# Altium schematic sheet S08_IO_RevF.SchDoc
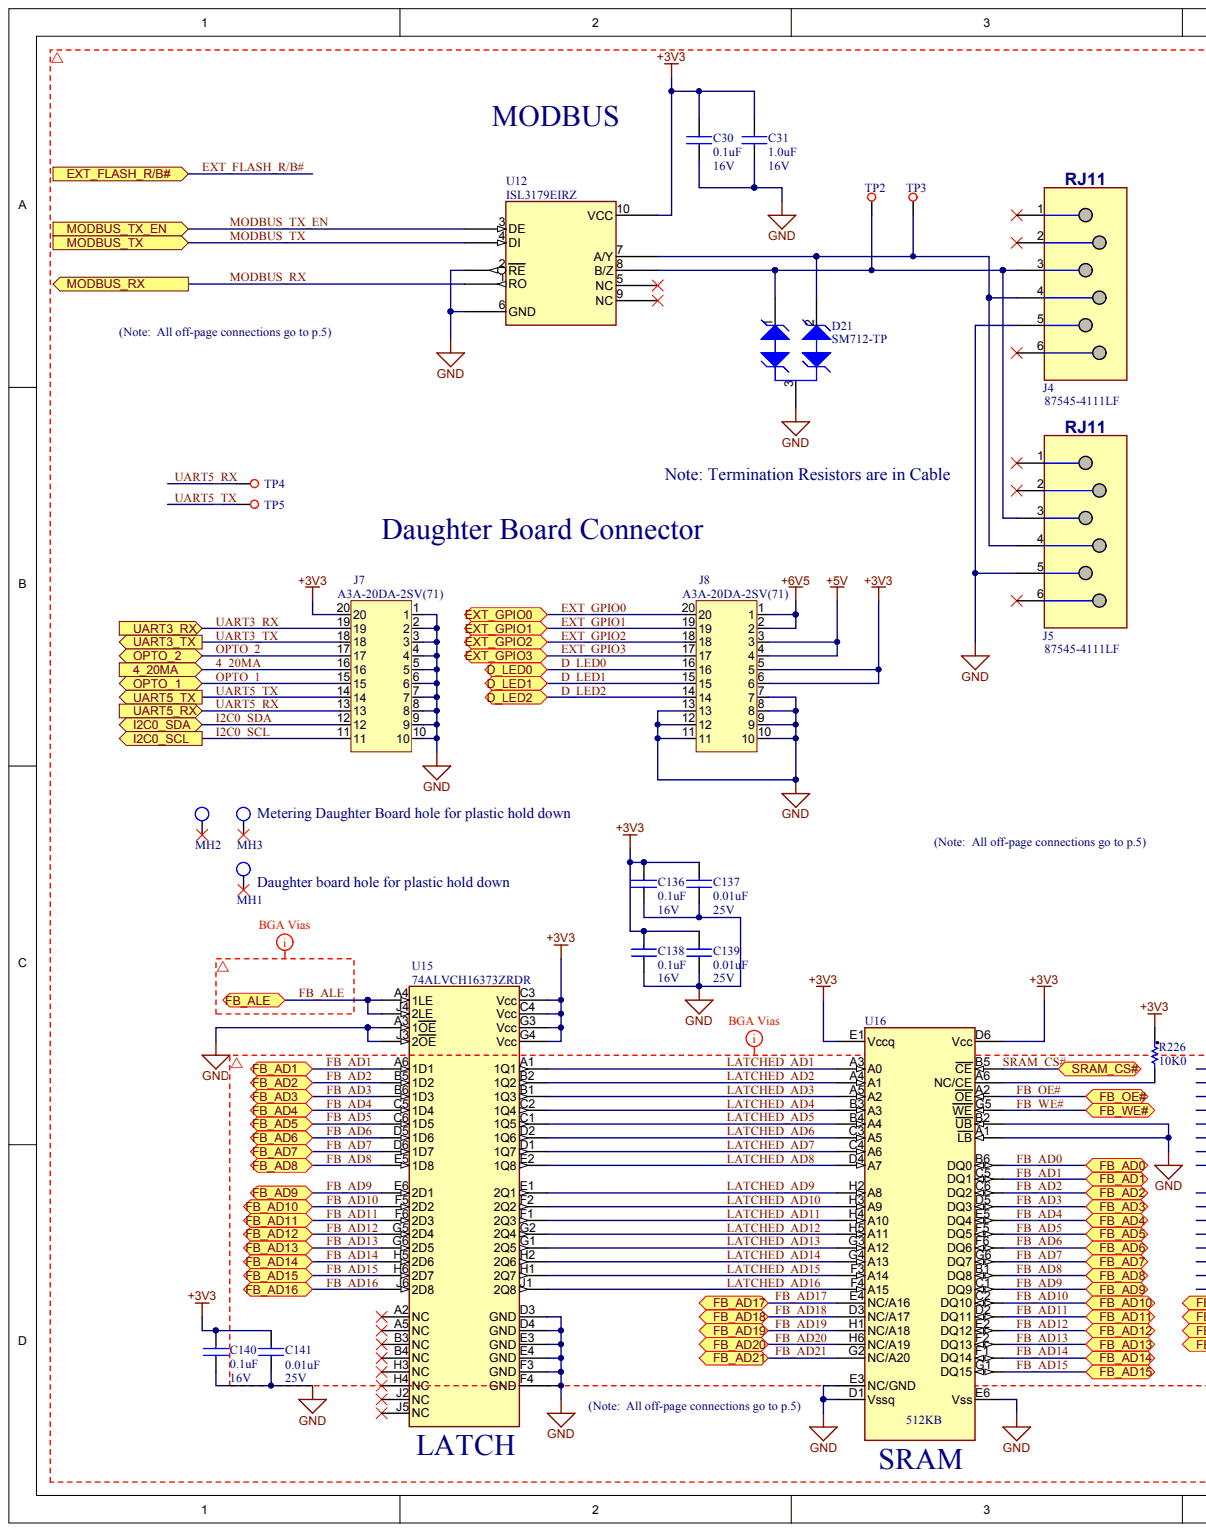
[diagram p0: S08_IO_RevF.SchDoc, left]
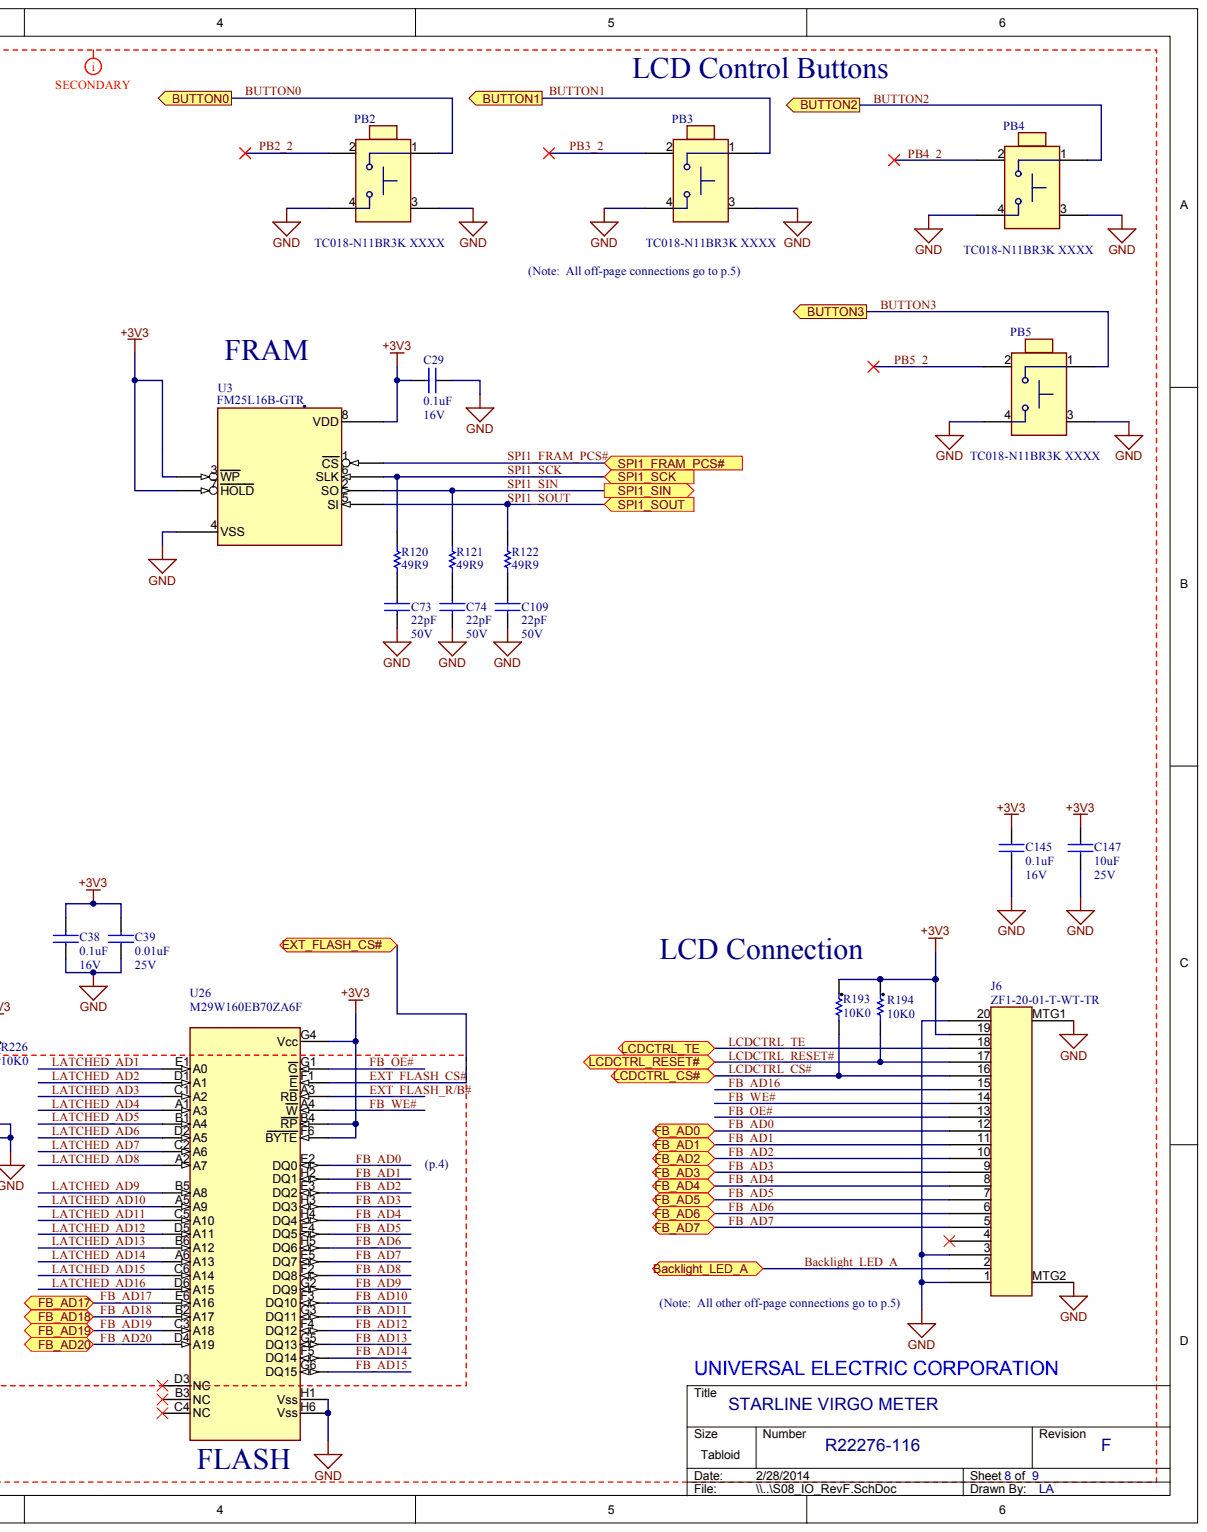
[diagram p1: S08_IO_RevF.SchDoc, right]

## Parts
C29  value 0.1uF  Voltage: 16V  (hidden comment: CAP CER 0.1uF X5R 10% 16V SM_0402)
C30  value 0.1uF  Voltage: 16V  (hidden comment: CAP CER 0.1uF X5R 10% 16V SM_0402)
C31  value 1.0uF  Voltage: 16V  (hidden comment: CAP CER 1.0uF X7R 20% 16V SM_0805)
C38  value 0.1uF  Voltage: 16V  (hidden comment: CAP CER 0.1uF X5R 10% 16V SM_0402)
C39  value 0.01uF  Voltage: 25V  (hidden comment: CAP CER 0.01uF X7R 10% 25V SM_0402)
C73  Voltage: 50V  (hidden comment: 22pF)
C74  Voltage: 50V  (hidden comment: 22pF)
C109  Voltage: 50V  (hidden comment: 22pF)
C136  value 0.1uF  Voltage: 16V  (hidden comment: CAP CER 0.1uF X5R 10% 16V SM_0402)
C137  value 0.01uF  Voltage: 25V  (hidden comment: CAP CER 0.01uF X7R 10% 25V SM_0402)
C138  value 0.1uF  Voltage: 16V  (hidden comment: CAP CER 0.1uF X5R 10% 16V SM_0402)
C139  value 0.01uF  Voltage: 25V  (hidden comment: CAP CER 0.01uF X7R 10% 25V SM_0402)
C140  value 0.1uF  Voltage: 16V  (hidden comment: CAP CER 0.1uF X5R 10% 16V SM_0402)
C141  Voltage: 25V  (hidden comment: 0.01uF)
C145  value 0.1uF  Voltage: 16V  (hidden comment: CAP CER 0.1uF X5R 10% 16V SM_0402)
C147  Voltage: 25V  (hidden comment: 10uF)
D21  SM712-TP
J4  value 87545-4111LF  MFG_PART_NO: 87545-4111LF  (hidden comment: RJ11 Modular Jack)
J5  value 87545-4111LF  MFG_PART_NO: 87545-4111LF  (hidden comment: RJ11 Modular Jack)
J6  ZF1-20-01-T-WT-TR
J7  A3A-20DA-2SV(71)
J8  A3A-20DA-2SV(71)
MH1  STANDOFF-MATE  (value not drawn)
MH2  STANDOFF-MATE  (value not drawn)
MH3  STANDOFF-MATE  (value not drawn)
PB2  MFG_PART_NO: TC018-N11BR3K  (hidden comment: TC018-N11BR3K XXXX)
PB3  MFG_PART_NO: TC018-N11BR3K  (hidden comment: TC018-N11BR3K XXXX)
PB4  MFG_PART_NO: TC018-N11BR3K  (hidden comment: TC018-N11BR3K XXXX)
PB5  MFG_PART_NO: TC018-N11BR3K  (hidden comment: TC018-N11BR3K XXXX)
R120  49R9
R121  49R9
R122  49R9
R193  10K0
R194  10K0
R226  10K0
TP2  =VALUE
TP3  =VALUE
TP4  =VALUE
TP5  =VALUE
U3  FM25L16B-GTR
U12  ISL3179EIRZ
U15  74ALVCH16373ZRDR
U16  512KB
U26  M29W160EB70ZA6F

## Ports
4_20MA, BACKLIGHT_LED_A, BUTTON0, BUTTON1, BUTTON2, BUTTON3, D_LED0, D_LED1, D_LED2, EXT_FLASH_CS#, EXT_FLASH_R/B#, EXT_GPIO0, EXT_GPIO1, EXT_GPIO2, EXT_GPIO3, FB_AD0, FB_AD1, FB_AD2, FB_AD3, FB_AD4, FB_AD5, FB_AD6, FB_AD7, FB_AD8, FB_AD9, FB_AD10, FB_AD11, FB_AD12, FB_AD13, FB_AD14, FB_AD15, FB_AD16, FB_AD17, FB_AD18, FB_AD19, FB_AD20, FB_AD21, FB_ALE, FB_OE#, FB_WE#, I2C0_SCL, I2C0_SDA, LCDCTRL_CS#, LCDCTRL_RESET#, LCDCTRL_TE, MODBUS_RX, MODBUS_TX, MODBUS_TX_EN, OPTO_1, OPTO_2, SPI1_FRAM_PCS#, SPI1_SCK, SPI1_SIN, SPI1_SOUT, SRAM_CS#, UART3_RX, UART3_TX, UART5_RX, UART5_TX

## Nets
+3V3: C29.2 C30.2 C31.2 C38.2 C39.2 C136.2 C137.2 C138.2 C139.2 C140.2 C141.2 C145.1 C147.1 J6.19 J7.20 J8.5 J8.6 R193.1 R194.1 R226.1 U3.3(WP) U3.7(HOLD) U3.8(VDD) U12.10(VCC) U15.C3(Vcc) U15.C4(Vcc) U15.G3(Vcc) U15.G4(Vcc) U16.D6(Vcc) U16.E1(Vccq) U26.B4(RP) U26.F6(BYTE) U26.G4(Vcc)
+5V: J8.3 J8.4
+6V5: J8.1 J8.2
GND: C29.1 C30.1 C31.1 C38.1 C39.1 C73.2 C74.2 C109.2 C136.1 C137.1 C138.1 C139.1 C140.1 C141.1 C145.2 C147.2 D21.3 J4.5 J5.5 J6.1 J6.3 J6.20 J6.MTG1 J6.MTG2 J7.1 J7.2 J7.3 J7.4 J7.5 J7.6 J7.7 J7.8 J7.9 J7.10 J8.7 J8.8 J8.9 J8.10 J8.11 J8.12 J8.13 PB2.3 PB2.4 PB3.3 PB3.4 PB4.3 PB4.4 PB5.3 PB5.4 U3.4(VSS) U12.2(RE) U12.6(GND) U15.A3(1OE) U15.D3(GND) U15.D4(GND) U15.E3(GND) U15.E4(GND) U15.F3(GND) U15.F4(GND) U15.J3(2OE) U16.A1(LB) U16.B2(UB) U16.D1(Vssq) U16.E3(NC/GND) U16.E6(Vss) U26.H1(Vss) U26.H6(Vss)
4_20MA: J7.16 ; port 4_20MA
BACKLIGHT_LED_A: J6.2 ; port BACKLIGHT_LED_A
BUTTON0: PB2.1 ; port BUTTON0
BUTTON1: PB3.1 ; port BUTTON1
BUTTON2: PB4.1 ; port BUTTON2
BUTTON3: PB5.1 ; port BUTTON3
D_LED0: J8.16 ; port D_LED0
D_LED1: J8.15 ; port D_LED1
D_LED2: J8.14 ; port D_LED2
EXT_FLASH_CS#: U26.F1(E) ; port EXT_FLASH_CS#
EXT_FLASH_R/B#: U26.A3(RB) ; port EXT_FLASH_R/B#
EXT_GPIO0: J8.20 ; port EXT_GPIO0
EXT_GPIO1: J8.19 ; port EXT_GPIO1
EXT_GPIO2: J8.18 ; port EXT_GPIO2
EXT_GPIO3: J8.17 ; port EXT_GPIO3
FB_AD0: J6.12 U16.B6(DQ0) U26.E2(DQ0) ; port FB_AD0
FB_AD1: J6.11 U15.A6(1D1) U16.C5(DQ1) U26.H2(DQ1) ; port FB_AD1
FB_AD2: J6.10 U15.B5(1D2) U16.C6(DQ2) U26.E3(DQ2) ; port FB_AD2
FB_AD3: J6.9 U15.B6(1D3) U16.D5(DQ3) U26.H3(DQ3) ; port FB_AD3
FB_AD4: J6.8 U15.C5(1D4) U16.E5(DQ4) U26.H4(DQ4) ; port FB_AD4
FB_AD5: J6.7 U15.C6(1D5) U16.F5(DQ5) U26.E4(DQ5) ; port FB_AD5
FB_AD6: J6.6 U15.D5(1D6) U16.F6(DQ6) U26.H5(DQ6) ; port FB_AD6
FB_AD7: J6.5 U15.D6(1D7) U16.G6(DQ7) U26.E5(DQ7) ; port FB_AD7
FB_AD8: U15.E5(1D8) U16.B1(DQ8) U26.F2(DQ8) ; port FB_AD8
FB_AD9: U15.E6(2D1) U16.C1(DQ9) U26.G2(DQ9) ; port FB_AD9
FB_AD10: U15.F5(2D2) U16.C2(DQ10) U26.F3(DQ10) ; port FB_AD10
FB_AD11: U15.F6(2D3) U16.D2(DQ11) U26.G3(DQ11) ; port FB_AD11
FB_AD12: U15.G5(2D4) U16.E2(DQ12) U26.F4(DQ12) ; port FB_AD12
FB_AD13: U15.G6(2D5) U16.F2(DQ13) U26.G5(DQ13) ; port FB_AD13
FB_AD14: U15.H5(2D6) U16.F1(DQ14) U26.F5(DQ14) ; port FB_AD14
FB_AD15: U15.H6(2D7) U16.G1(DQ15) U26.G6(DQ15) ; port FB_AD15
FB_AD16: J6.15 U15.J6(2D8) ; port FB_AD16
FB_AD17: U16.E4(NC/A16) U26.E6(A16) ; port FB_AD17
FB_AD18: U16.D3(NC/A17) U26.B2(A17) ; port FB_AD18
FB_AD19: U16.H1(NC/A18) U26.C3(A18) ; port FB_AD19
FB_AD20: U16.H6(NC/A19) U26.D4(A19) ; port FB_AD20
FB_AD21: U16.G2(NC/A20) ; port FB_AD21
FB_ALE: U15.A4(1LE) U15.J4(2LE) ; port FB_ALE
FB_OE#: J6.13 U16.A2(OE) U26.G1(G) ; port FB_OE#
FB_WE#: J6.14 U16.G5(WE) U26.A4(W) ; port FB_WE#
I2C0_SCL: J7.11 ; port I2C0_SCL
I2C0_SDA: J7.12 ; port I2C0_SDA
LATCHED_AD1: U15.A1(1Q1) U16.A3(A0) U26.E1(A0)
LATCHED_AD2: U15.B2(1Q2) U16.A4(A1) U26.D1(A1)
LATCHED_AD3: U15.B1(1Q3) U16.A5(A2) U26.C1(A2)
LATCHED_AD4: U15.C2(1Q4) U16.B3(A3) U26.A1(A3)
LATCHED_AD5: U15.C1(1Q5) U16.B4(A4) U26.B1(A4)
LATCHED_AD6: U15.D2(1Q6) U16.C3(A5) U26.D2(A5)
LATCHED_AD7: U15.D1(1Q7) U16.C4(A6) U26.C2(A6)
LATCHED_AD8: U15.E2(1Q8) U16.D4(A7) U26.A2(A7)
LATCHED_AD9: U15.E1(2Q1) U16.H2(A8) U26.B5(A8)
LATCHED_AD10: U15.F2(2Q2) U16.H3(A9) U26.A5(A9)
LATCHED_AD11: U15.F1(2Q3) U16.H4(A10) U26.C5(A10)
LATCHED_AD12: U15.G2(2Q4) U16.H5(A11) U26.D5(A11)
LATCHED_AD13: U15.G1(2Q5) U16.G3(A12) U26.B6(A12)
LATCHED_AD14: U15.H2(2Q6) U16.G4(A13) U26.A6(A13)
LATCHED_AD15: U15.H1(2Q7) U16.F3(A14) U26.C6(A14)
LATCHED_AD16: U15.J1(2Q8) U16.F4(A15) U26.D6(A15)
LCDCTRL_CS#: J6.16 R193.2 ; port LCDCTRL_CS#
LCDCTRL_RESET#: J6.17 R194.2 ; port LCDCTRL_RESET#
LCDCTRL_TE: J6.18 ; port LCDCTRL_TE
MODBUS_RX: U12.1(RO) ; port MODBUS_RX
MODBUS_TX: U12.4(DI) ; port MODBUS_TX
MODBUS_TX_EN: U12.3(DE) ; port MODBUS_TX_EN
OPTO_1: J7.15 ; port OPTO_1
OPTO_2: J7.17 ; port OPTO_2
PB2_2: PB2.2
PB3_2: PB3.2
PB4_2: PB4.2
PB5_2: PB5.2
SPI1_FRAM_PCS#: U3.1(CS) ; port SPI1_FRAM_PCS#
SPI1_SCK: R120.2 U3.6(SLK) ; port SPI1_SCK
SPI1_SIN: R121.2 U3.2(SO) ; port SPI1_SIN
SPI1_SOUT: R122.2 U3.5(SI) ; port SPI1_SOUT
SRAM_CS#: U16.B5(CE) ; port SRAM_CS#
UART3_RX: J7.19 ; port UART3_RX
UART3_TX: J7.18 ; port UART3_TX
UART5_RX: J7.13 TP4.1 ; port UART5_RX
UART5_TX: J7.14 TP5.1 ; port UART5_TX
NetC73_1: C73.1 R120.1
NetC74_1: C74.1 R121.1
NetC109_1: C109.1 R122.1
NetD21_1: D21.1 J4.3 J5.3 TP2.1 U12.8(B/Z)
NetD21_2: D21.2 J4.4 J5.4 TP3.1 U12.7(A/Y)
NetR226_2: R226.2 U16.A6(NC/CE)
N00488:
N00489:
N00490:

Not connected: J4 1 2 6; J5 1 2 6; J6 4; MH1 1; MH2 1; MH3 1; U12 5(NC) 9(NC); U15 A2(NC) A5(NC) B3(NC) B4(NC) H3(NC) H4(NC) J2(NC) J5(NC); U26 B3(NC) C4(NC) D3(NC)

## Library data of the parts
C29 C30 C38 C136 C138 C140 C145 = (no symbol name): MFG_PART_NO: GRM155R71C104KA88D; MFG: Murata
C31 = (no symbol name): MFG_PART_No: C2012X7R1C105K; MFG: TDK
C39 C137 C139 C141 = (no symbol name): MFG_PART_NO: GRM155R71E103KA01D; MFG: Murata
  C141: Value: 0.01uF
C73 C74 C109 = (no symbol name): MFG_PART_NO: CC0402JRNPO9BN220; MFG: Yageo; Value: 22pF
C147 = (no symbol name): MFG_PART_NO: C3216X7R1E106K; MFG: TDK; Value: 10uF
D21 = (no symbol name): SYM_LVL: LEVEL1; LEVEL: Level_1; MFG: Micro Commercial Co; TYPE: Diode; NUV_DESCRIPTION: TVS DIODE 12V 400W 2CH BI SOT23; PCBLIB: UEC01.PcbLib; ROOM: *; NOSTUFF: *; MFG_PART_NO: SM712-TP; VALUE: SM712-TP; PACKAGE: SMT; ROHS: RoHS; LAST_UPDATE: 7/26/2012; Created By: K Desrochers
J4 J5 = (no symbol name): LEVEL: Level_1; PCBLIB: UEC01.PcbLib; MFG: FCI; NUV_DESCRIPTION: RJ11 CONN MOD JACK 6P6C; TYPE: Connector; PACKAGE: SMT; ROHS: RoHS; LAST_UPDATE: 6/21/2012; Created By: K Desrochers
J6 = (no symbol name): SYM_LVL: LEVEL1; LEVEL: Level_1; MFG: Samtec; NUV_DESCRIPTION: CONN FPC/FFC 20POS 1MM HORZ SMD; NOSTUFF: *; ROOM: *; MFG_PART_NO: ZF1-20-01-T-WT-TR; TYPE: Connector; VALUE: ZF1-20-01-T-WT-TR; PACKAGE: SMT; ROHS: RoHS; LAST_UPDATE: 6/27/2012; Created By: K Desrochers
J7 J8 = (no symbol name): LEVEL: Level_1; PCBLIB: UEC01.PcbLib; MFG: Hirose Electric Co Ltd; NUV_DESCRIPTION: CONN RECEPT 2MM DOUBLE SMD 20POS; MFG_PART_NO: A3A-20DA-2SV(71); TYPE: Connector; VALUE: A3A-20DA-2SV(71); PACKAGE: SMT; ROHS: RoHS; Created By: H.Munir
MH1-MH3 = (no symbol name): MFG_PART_NO: MDLSP1-07M-01; PCBLIB: UEC01.PcbLib; MFG: RICHCO, INC.; VALUE: 7mm; TYPE: Standoff; PACKAGE: Thru-Hole
PB2-PB5 = (no symbol name): LEVEL: Level_1; PCBLIB: UEC01.PcbLib; MFG: Well-Buying Industrial Co Ltd; NUV_DESCRIPTION: Switch Tactile OFF (ON) SPST Rount Button, IP67, 6x6mm, 0.06A 24VDC, SMD; TYPE: Switch/button; VALUE: TC018-N11BR3K XXXX; PACKAGE: SMT; ROHS: RoHS; Created By: M Ball
R120 = (no symbol name): MFG_PART_NO: RC0402FR-0749R9L; SYM_LVL: LEVEL1; LEVEL: Level_1; PCBLIB: UEC01.PcbLib; MFG: Yageo; TOLERANCE: +/-1%; ROOM: *; NOSTUFF: *; VOLTAGE: =VOLTAGE; VALUE: 49R9; TYPE: Resistor; PACKAGE: SMT; ROHS: RoHS; Created By: H.Munir
R121 R122 = (no symbol name): MFG_PART_NO: RC0402FR-0749R9L; SYM_LVL: LEVEL1; LEVEL: Level_1; PCBLIB: UEC01.PcbLib; MFG: Yageo; TOLERANCE: +/-1%; NUV_DESCRIPTION: RES 50OHM 1/16W SMD; ROOM: *; NOSTUFF: *; VOLTAGE: =VOLTAGE; VALUE: 49R9; TYPE: Resistor; PACKAGE: SMT; ROHS: RoHS; Created By: H.Munir
R193 R194 R226 = (no symbol name): Part Number: CRCW040210K0FKED; Manufacturer: Vishay; Power: 1/16W; Tolerance: 0.01; RESISTANCE: 10K0; Manufacturer P/N: CRCW040210K0FKED; Package: SMT; Type: Resistor
TP2 TP4 TP5 = (no symbol name): SYM_LVL: LEVEL1; LEVEL: Level_1; NUV_DESCRIPTION: Test Point; ROOM: *; NOSTUFF: NOSTUFF; TYPE: Test Point; PACKAGE: SMT; ROHS: RoHS; LAST_UPDATE: 6/21/2012; Created By: K Desrochers
TP3 = (no symbol name): SYM_LVL: LEVEL1; LEVEL: Level_1; NUV_DESCRIPTION: Test Point; Rule: UNIONINDEX{}0[]RULEKIND{}PermittedLayers[]NETSCOPE{}AnyNet[]LAYERKIND{}SameLayer[]NAME{}Schematic Permitted Layers Rule[]TOPLAYERPERMITTED{}TRUE[]BOTTOMLAYERPERMITTED{}FALSE[]UNIT{}Imperial[]PcbType{}ProtelPcb; ROOM: *; NOSTUFF: NOSTUFF; TYPE: Test Point; PACKAGE: SMT; ROHS: RoHS; LAST_UPDATE: 6/21/2012; Created By: K Desrochers
U3 = (no symbol name): SYM_LVL: LEVEL1; LEVEL: Level_1; MFG: Ramtron; NUV_DESCRIPTION: IC FRAM 16KBIT SPI 20MHZ 8SOIC; NOSTUFF: *; ROOM: *; MFG_PART_NO: FM25L16B-GTR; TYPE: IC; VALUE: FM25L16B-GTR; PACKAGE: SMT; ROHS: RoHS; LAST_UPDATE: 6/26/2012; Created By: K Desrochers
U12 = (no symbol name): LEVEL: Level_1; Changed By: H.Munir; PCBLIB: UEC01.PcbLib; MFG: Intersil; NUV_DESCRIPTION: TXRX ESD 3.3V RS-485/422 10-DFN; MFG_PART_NO: ISL3179EIRZ; TYPE: IC; VALUE: ISL3179EIRZ; PACKAGE: SMT; ROHS: RoHS; LAST_UPDATE: 6/26/2012; Checked By: N.Wennyk; Created By: K Desrochers
U15 = (no symbol name): LEVEL: Level_1; PCBLIB: UEC01.PcbLib; MFG: Texas Instrument; NUV_DESCRIPTION: IC 16-BIT TRANSPARENT D-TYPE LATCH BGA; MFG_PART_NO: 74ALVCH16373ZRDR; TYPE: IC; VALUE: 74ALVCH16373ZRDR; PACKAGE: SMT; ROHS: RoHS; Created By: H.Munir
U16 = (no symbol name): MFG_PART_NO: IS61WV25616BLL-10BLI; PCBLIB: UEC01.PcbLib; MFG: ISSI; NUV_DESCRIPTION: IC SRAM 4MBIT 48MBGA; NOSTUFF: *; ROOM: *; TYPE: IC; VALUE: 512KB; ROHS: RoHS
U26 = (no symbol name): MFG: Numonyx; MFG_PART_NO: M29W160EB70ZA6F; VALUE: M29W160EB70ZA6F
